# Altium schematic sheet cpupwrbackup.SchDoc(cpupwrbackup)
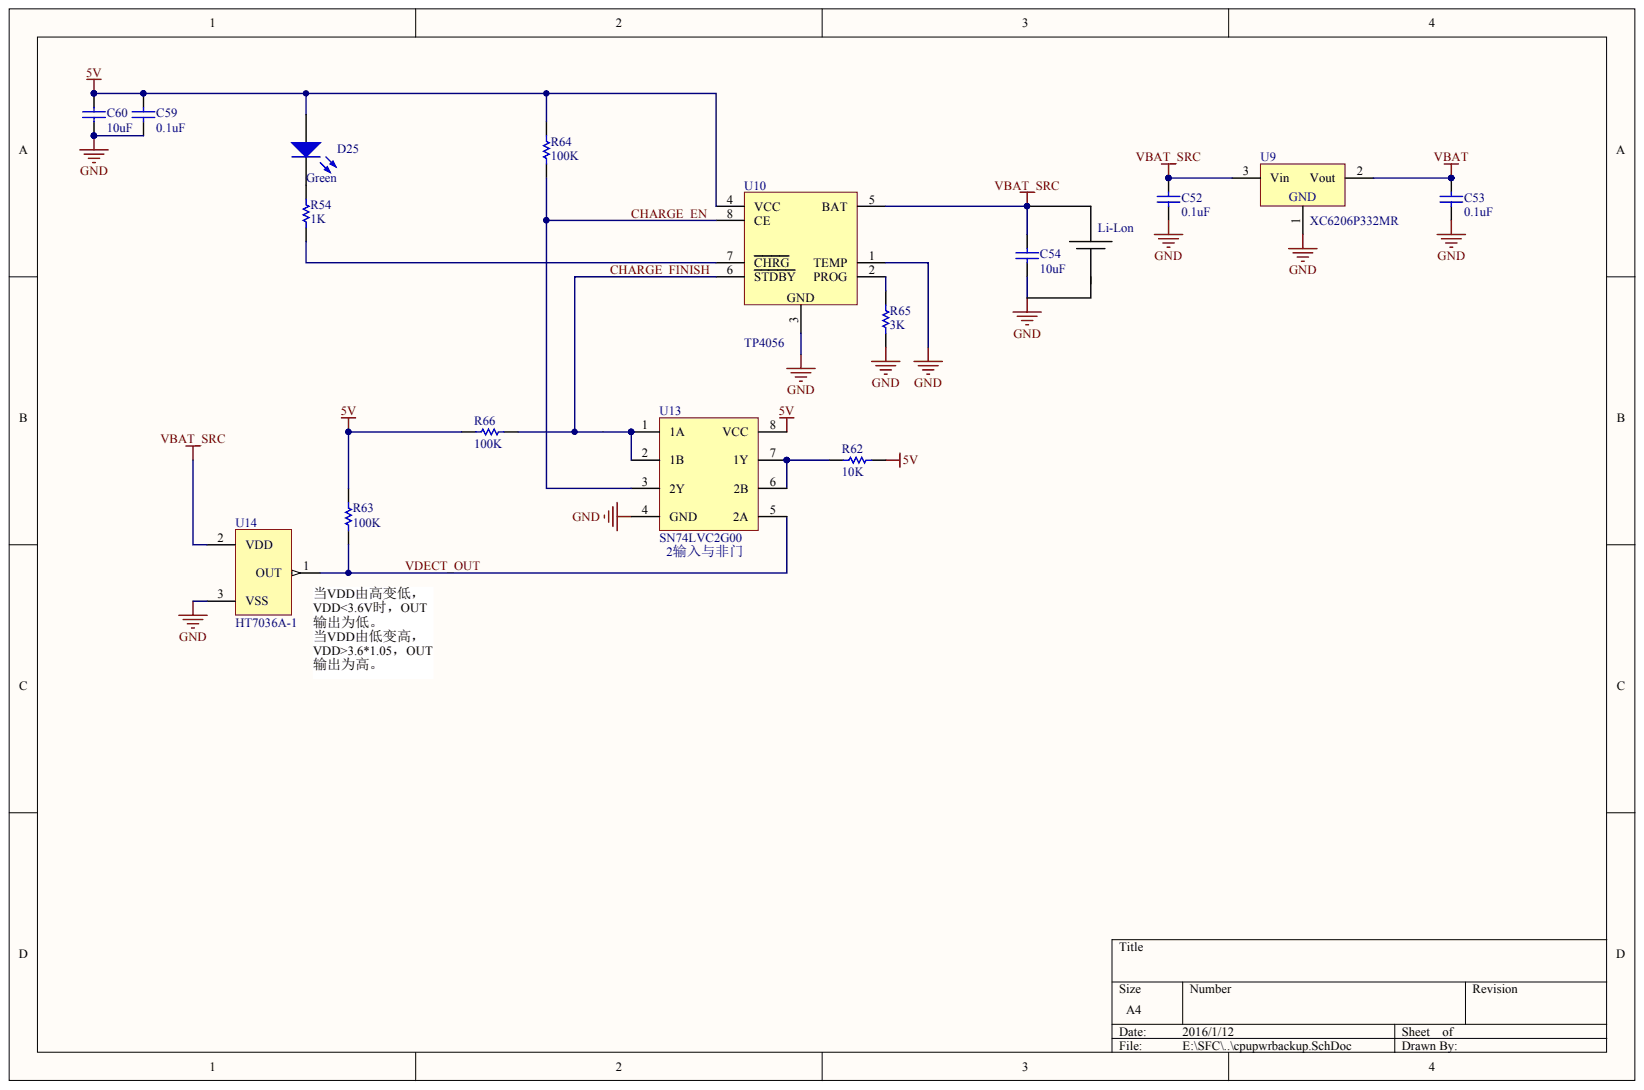
[diagram p0: cpupwrbackup.SchDoc]

## Parts
C52  0.1uF
C53  0.1uF
C54  10uF
C59  0.1uF
C60  10uF
D25  Green
R54  1K
R62  10K
R63  100K
R64  100K
R65  3K
R66  100K
U9  XC6206P332MR
U10  TP4056
U13  SN74LVC2G00
U14  HT7036A-1

## Nets
5V: C59.1 C60.1 D25.1 R62.1 R63.2 R64.2 R66.2 U10.4(VCC) U13.8(VCC)
GND: C52.2 C53.2 C54.2 C59.2 C60.2 R65.1 U9.1 U10.1(TEMP) U10.3 U13.4(GND) U14.3
VBAT: C53.1 U9.2
VBAT_SRC: C52.1 C54.1 U9.3 U10.5(BAT) U14.2
CHARGE_EN: R64.1 U10.8(CE) U13.3(2Y)
CHARGE_FINISH: R66.1 U10.6(STDBY) U13.1(1A) U13.2(1B)
VDECT_OUT: R63.1 U13.5(2A) U14.1
NetD25_2: D25.2 R54.1
NetR54_2: R54.2 U10.7(CHRG)
NetR62_2: R62.2 U13.6(2B) U13.7(1Y)
NetR65_2: R65.2 U10.2(PROG)

## Library data of the parts
C52-C54 C59 C60 = (no symbol name): Value: 1nF; Published: 8-Jun-2000; LatestRevisionDate: 17-Jul-2002; LatestRevisionNote: Re-released for DXP Platform.; PackageReference: RAD-0.3; Publisher: Altium Limited
R54 R63-R66 = (no symbol name): Value: 10K; Published: 8-Jun-2000; LatestRevisionDate: 17-Jul-2002; LatestRevisionNote: Re-released for DXP Platform.; PackageReference: AXIAL-0.3; Publisher: Altium Limited
D25 R62 U9 U10 U13 U14 = (no symbol name)
  D25: Published: 8-Jun-2000; LatestRevisionDate: 08-Jul-2005; LatestRevisionNote: Mech Layer 1 removed.; PackageReference: LED-1
  R62: Published: 8-Jun-2000; LatestRevisionDate: 17-Jul-2002; LatestRevisionNote: Re-released for DXP Platform.; PackageReference: AXIAL-0.3; Value: 10K
  D25 R62: Publisher: Altium Limited
